# Altium schematic sheet C_20.SchDoc
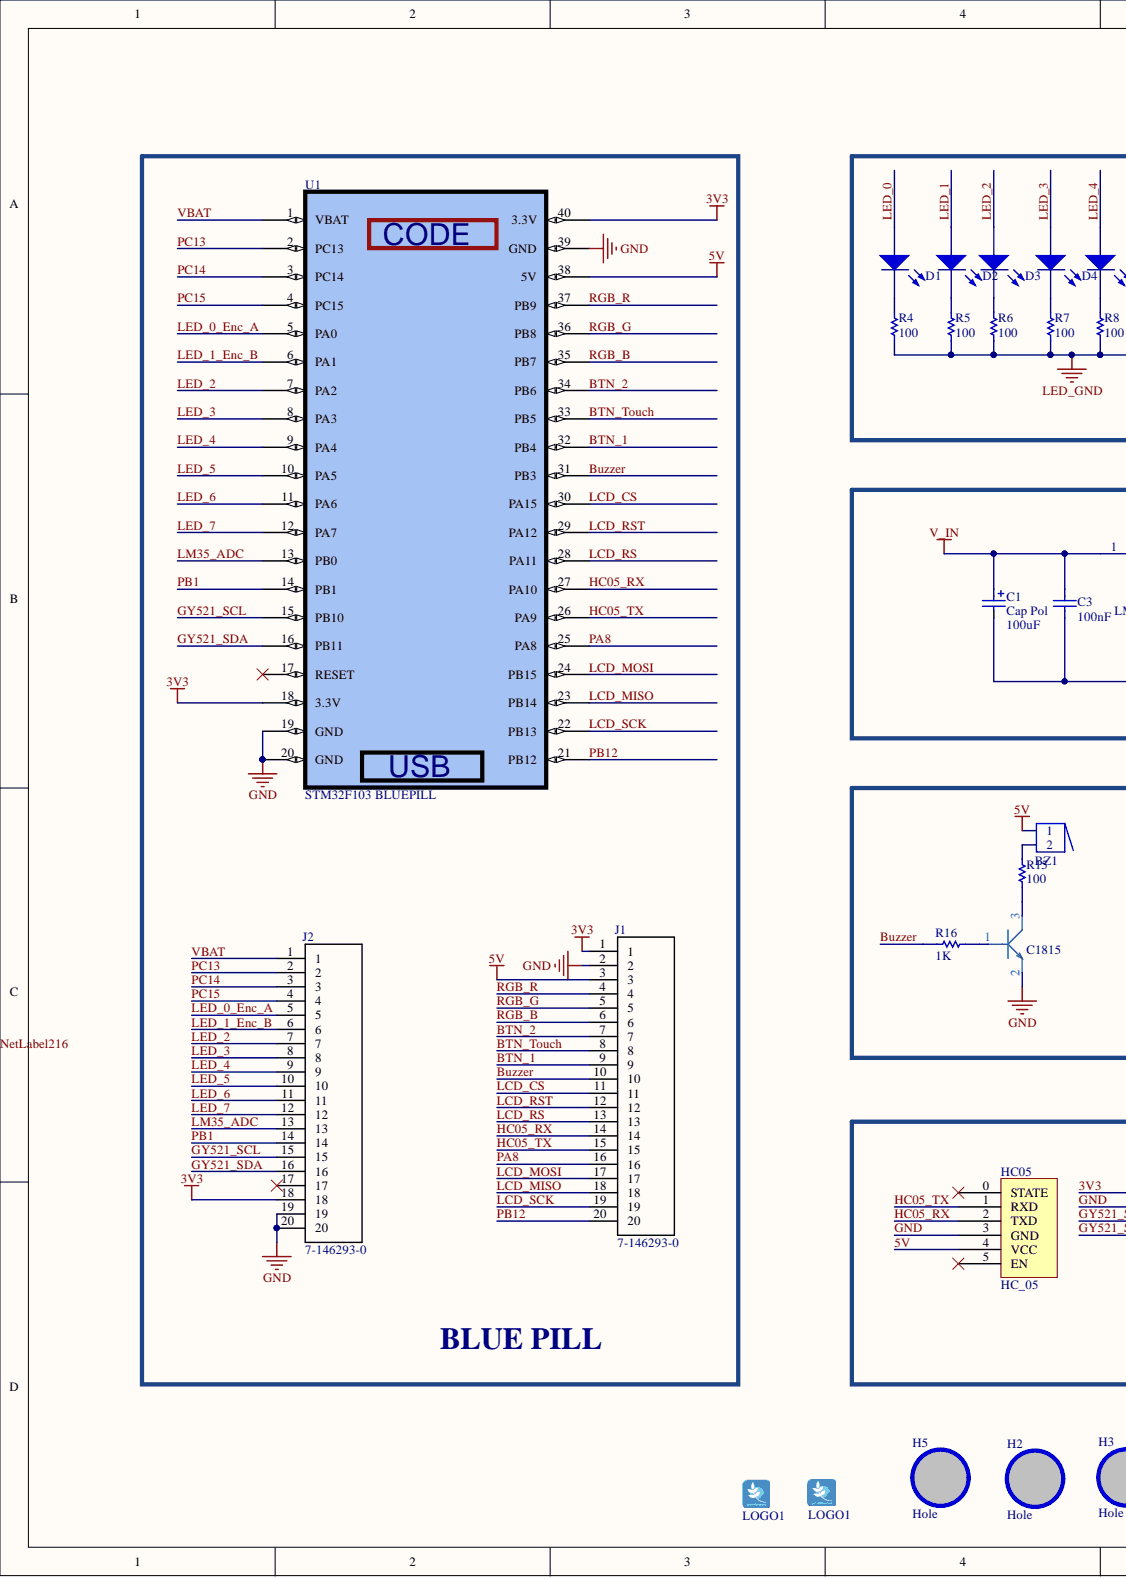
[diagram p0: C_20.SchDoc, left]
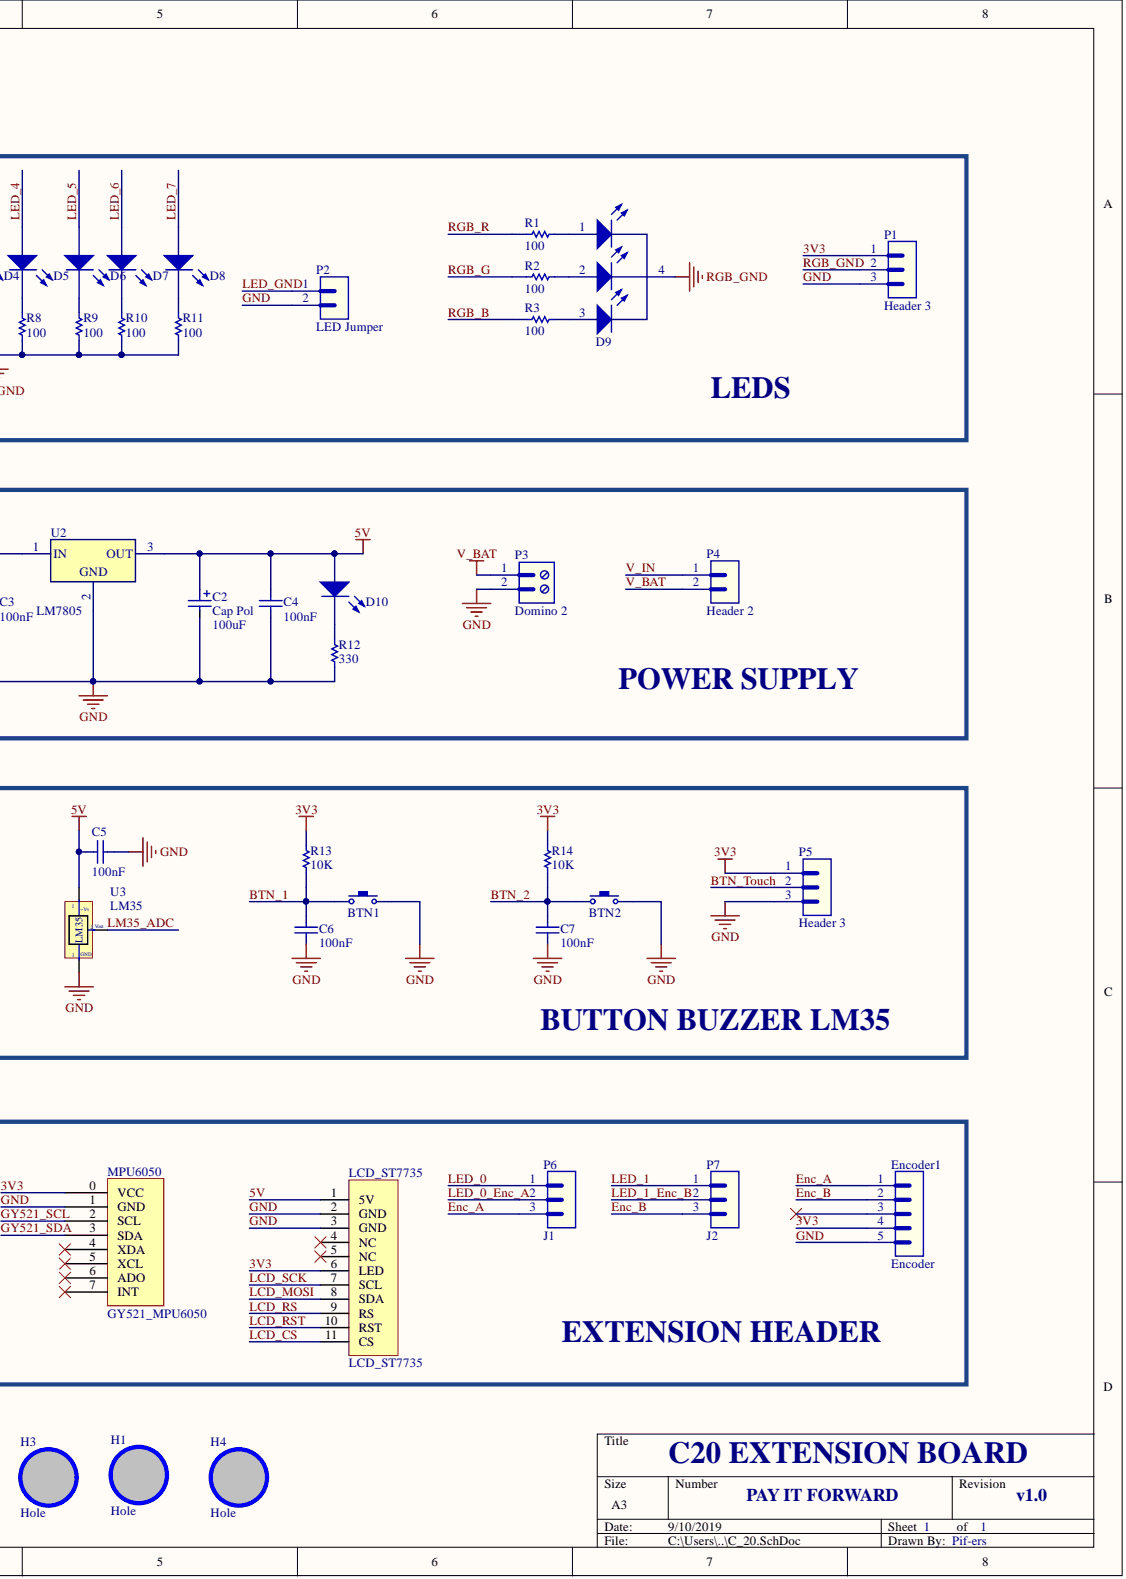
[diagram p1: C_20.SchDoc, right]

## Parts
BTN1  Button  [Button]  (value not drawn)
BTN2  Button  [Button]  (value not drawn)
BZ1  Buzzer  [BUZZER]  (value not drawn)
C1  Cap Pol  value 100uF  [CP1/2]
C2  Cap Pol  value 100uF  [CP1/2]
C3  value 100nF  [CAP-SM-0805]  (hidden comment: Cap Non-Pol SMD)
C4  value 100nF  [CAP-SM-0805]  (hidden comment: Cap Non-Pol SMD)
C5  value 100nF  [CAP-SM-0805]  (hidden comment: Cap Non-Pol SMD)
C6  value 100nF  [CAP-SM-0805]  (hidden comment: Cap Non-Pol SMD)
C7  value 100nF  [CAP-SM-0805]  (hidden comment: Cap Non-Pol SMD)
C8  C1815  [C1815_SMD]
D1  LED  [LED-3mm]
D2  LED  [LED-3mm]
D3  LED  [LED-3mm]
D4  LED  [LED-3mm]
D5  LED  [LED-3mm]
D6  LED  [LED-3mm]
D7  LED  [LED-3mm]
D8  LED  [LED-3mm]
D9  LED RGB  [LED RGB]  (value not drawn)
D10  LED  [LED-3mm]  (value not drawn)
Encoder1  Encoder  [JP5]
H1  Hole  [LO KHOAN 3MM]
H2  Hole  [LO KHOAN 3MM]
H3  Hole  [LO KHOAN 3MM]
H4  Hole  [LO KHOAN 3MM]
H5  Hole  [LO KHOAN 3MM]
HC05  HC_05  [HC_05]
J1  7-146293-0  [*TE_7-146293-0]
J2  7-146293-0  [*TE_7-146293-0]
LCD_ST7735  LCD_ST7735  [LCD_ST7735]
LOGO1  LOGO PIF  [PIF LOGO BOTTOM LAYER]  (value not drawn)
LOGO1  LOGO PIF  [PIF LOGO TOP OVERLAY]  (value not drawn)
MPU6050  GY521_MPU6050  [GY521_MPU6050]
P1  Header 3  [JP3]
P2  LED Jumper  [JP2]
P3  Domino 2  [DOMINO 2- 5mm]
P4  Header 2  [JP2]
P5  Header 3  [JP3]
P6  J1  [JP3]
P7  J2  [JP3]
R1  value 100  [RES-SM-0805]  (hidden comment: Resistor 1/4W)
R2  value 100  [RES-SM-0805]  (hidden comment: Resistor 1/4W)
R3  value 100  [RES-SM-0805]  (hidden comment: Resistor 1/4W)
R4  value 100  [RES-SM-0805]  (hidden comment: Resistor 1/4W)
R5  value 100  [RES-SM-0805]  (hidden comment: Resistor 1/4W)
R6  value 100  [RES-SM-0805]  (hidden comment: Resistor 1/4W)
R7  value 100  [RES-SM-0805]  (hidden comment: Resistor 1/4W)
R8  value 100  [RES-SM-0805]  (hidden comment: Resistor 1/4W)
R9  value 100  [RES-SM-0805]  (hidden comment: Resistor 1/4W)
R10  value 100  [RES-SM-0805]  (hidden comment: Resistor 1/4W)
R11  value 100  [RES-SM-0805]  (hidden comment: Resistor 1/4W)
R12  value 330  [RES-SM-0805]  (hidden comment: Resistor 1/4W)
R13  value 10K  [RES-SM-0805]  (hidden comment: Resistor 1/4W)
R14  value 10K  [RES-SM-0805]  (hidden comment: Resistor 1/4W)
R15  value 100  [RES-SM-0805]  (hidden comment: Resistor 1/4W)
R16  value 1K  [RES-SM-0805]  (hidden comment: Resistor 1/4W)
U1  STM32F103 BLUEPILL  [STM32F103 Bluepill]
U2  LM7805  [QTO-220]
U3  LM35  [QTO-92]

## Nets
3V3: Encoder1.4 J1.1 J2.18 LCD_ST7735.6(LED) MPU6050.0(VCC) P1.1 P5.1 R13.2 R14.2 U1.18(3.3V) U1.40(3.3V)
5V: BZ1.1 C2.1 C4.2 C5.1 D10.1 HC05.4(VCC) J1.3 LCD_ST7735.1(5V) U1.38(5V) U2.3 U3.1
BTN_1: BTN1.1 C6.2 J1.9 R13.1 U1.32(PB4)
BTN_2: BTN2.1 C7.2 J1.7 R14.1 U1.34(PB6)
BTN_Touch: J1.8 P5.2 U1.33(PB5)
Buzzer: J1.10 R16.1 U1.31(PB3)
Enc_A: Encoder1.1 P6.3
Enc_B: Encoder1.2 P7.3
GND: BTN1.2 BTN2.2 C1.2 C2.2 C3.1 C4.1 C5.2 C6.1 C7.1 C8.2 Encoder1.5 HC05.3(GND) J1.2 J2.19 J2.20 LCD_ST7735.2(GND) LCD_ST7735.3(GND) MPU6050.1(GND) P1.3 P2.2 P3.2 P5.3 R12.1 U1.19(GND) U1.20(GND) U1.39(GND) U2.2 U3.3
GY521_SCL: J2.15 MPU6050.2(SCL) U1.15(PB10)
GY521_SDA: J2.16 MPU6050.3(SDA) U1.16(PB11)
HC05_RX: HC05.2(TXD) J1.14 U1.27(PA10)
HC05_TX: HC05.1(RXD) J1.15 U1.26(PA9)
LCD_CS: J1.11 LCD_ST7735.11(CS) U1.30(PA15)
LCD_MISO: J1.18 U1.23(PB14)
LCD_MOSI: J1.17 LCD_ST7735.8(SDA) U1.24(PB15)
LCD_RS: J1.13 LCD_ST7735.9(RS) U1.28(PA11)
LCD_RST: J1.12 LCD_ST7735.10(RST) U1.29(PA12)
LCD_SCK: J1.19 LCD_ST7735.7(SCL) U1.22(PB13)
LED_0: D1.1 P6.1
LED_0_Enc_A: J2.5 P6.2 U1.5(PA0)
LED_1: D2.1 P7.1
LED_1_Enc_B: J2.6 P7.2 U1.6(PA1)
LED_2: D3.1 J2.7 U1.7(PA2)
LED_3: D4.1 J2.8 U1.8(PA3)
LED_4: D5.1 J2.9 U1.9(PA4)
LED_5: D6.1 J2.10 U1.10(PA5)
LED_6: D7.1 J2.11 U1.11(PA6)
LED_7: D8.1 J2.12 U1.12(PA7)
LED_GND: P2.1 R4.1 R5.1 R6.1 R7.1 R8.1 R9.1 R10.1 R11.1
LM35_ADC: J2.13 U1.13(PB0) U3.2
PA8: J1.16 U1.25(PA8)
PB1: J2.14 U1.14(PB1)
PB12: J1.20 U1.21(PB12)
PC13: J2.2 U1.2(PC13)
PC14: J2.3 U1.3(PC14)
PC15: J2.4 U1.4(PC15)
RGB_B: J1.6 R3.1 U1.35(PB7)
RGB_G: J1.5 R2.1 U1.36(PB8)
RGB_GND: D9.4 P1.2
RGB_R: J1.4 R1.1 U1.37(PB9)
V_BAT: P3.1 P4.2
V_IN: C1.1 C3.2 P4.1 U2.1
VBAT: J2.1 U1.1(VBAT)
NetBZ1_2: BZ1.2 R15.2
NetC8_1: C8.1 R16.2
NetC8_3: C8.3 R15.1
NetD1_2: D1.2 R4.2
NetD2_2: D2.2 R5.2
NetD3_2: D3.2 R6.2
NetD4_2: D4.2 R7.2
NetD5_2: D5.2 R8.2
NetD6_2: D6.2 R9.2
NetD7_2: D7.2 R10.2
NetD8_2: D8.2 R11.2
NetD9_1: D9.1 R1.2
NetD9_2: D9.2 R2.2
NetD9_3: D9.3 R3.2
NetD10_2: D10.2 R12.2
NetLabel216:

Not connected: Encoder1 3; HC05 0(STATE) 5(EN); J2 17; LCD_ST7735 4(NC) 5(NC); MPU6050 4(XDA) 5(XCL) 6(ADO) 7(INT); U1 17(RESET)

## Library data of the parts
C1 C2 = Cap Pol: Polarized Capacitor (Radial); Component Kind: Standard; Library Name: PIF_C16_2_lib.IntLib
C3-C7 = Cap Non-Pol SMD: Capacitor; Component Kind: Standard; Library Name: PIF_C16_2_lib.IntLib
Encoder1 = Header 5: Header, 5-Pin; Component Kind: Standard; LatestRevisionDate: 17-Jul-2002; LatestRevisionNote: Re-released for DXP Platform.; Library Name: PIF_C16_2_lib.IntLib; Publisher: Altium Limited
H1-H5 = Hole: Hole 3mm; Component Kind: Standard; Library Name: PIF_C16_2_lib.IntLib
P1 P5-P7 = Header 3: Header, 3-Pin; Component Kind: Standard; LatestRevisionDate: 17-Jul-2002; LatestRevisionNote: Re-released for DXP Platform.; Library Name: PIF_C16_2_lib.IntLib; Publisher: Altium Limited
P2 P4 = Header 2: Header, 2-Pin; Component Kind: Standard; LatestRevisionDate: 17-Jul-2002; LatestRevisionNote: Re-released for DXP Platform.; Library Name: PIF_C16_2_lib.IntLib; Publisher: Altium Limited
P3 = Domino 2: Domino, 2-Pin; Component Kind: Standard; LatestRevisionDate: 17-Jul-2002; LatestRevisionNote: Re-released for DXP Platform.; Library Name: PIF_C16_2_lib.IntLib; Publisher: Altium Limited
R1-R16 = RES: Component Kind: Standard; Library Name: PIF_C16_2_lib.IntLib
U2 = LM7805: Positive Voltage Regulator; Component Kind: Standard; Library Name: PIF_C16_2_lib.IntLib
U3 = LM35: Teminal; Component Kind: Standard; Value: LM35; Library Name: syhaunguyen.IntLib
BTN1 BTN2 = Button: Component Kind: Standard; Library Name: PIF_C16_2_lib.IntLib
BZ1 = Buzzer: Component Kind: Standard; Library Name: PIF_C16_2_lib.IntLib
C8 = C1815: Component Kind: Standard; Library Name: C_20_addition.SchLib
D1-D8 D10 = LED: Component Kind: Standard; Library Name: PIF_C16_2_lib.IntLib
D9 = LED RGB: Component Kind: Standard; Library Name: PIF_C16_2_lib.IntLib
HC05 = HC_05: Component Kind: Standard; Library Name: C_20_addition.SchLib
J1 J2 = 7-146293-0: Component Kind: Standard; Library Name: 7-146293-0.IntLib
LCD_ST7735 = LCD_ST7735: Component Kind: Standard; Library Name: C_20_addition.SchLib
LOGO1 LOGO1 = LOGO PIF: Component Kind: Standard; Library Name: logo_PIF.IntLib
MPU6050 = GY521_MPU6050: Component Kind: Standard; Library Name: C_20_addition.SchLib
U1 = STM32F103 BLUEPILL: Component Kind: Standard; Library Name: BluePillLib.IntLib
